# Altium schematic sheet 07_300WDC_POWER.SchDoc
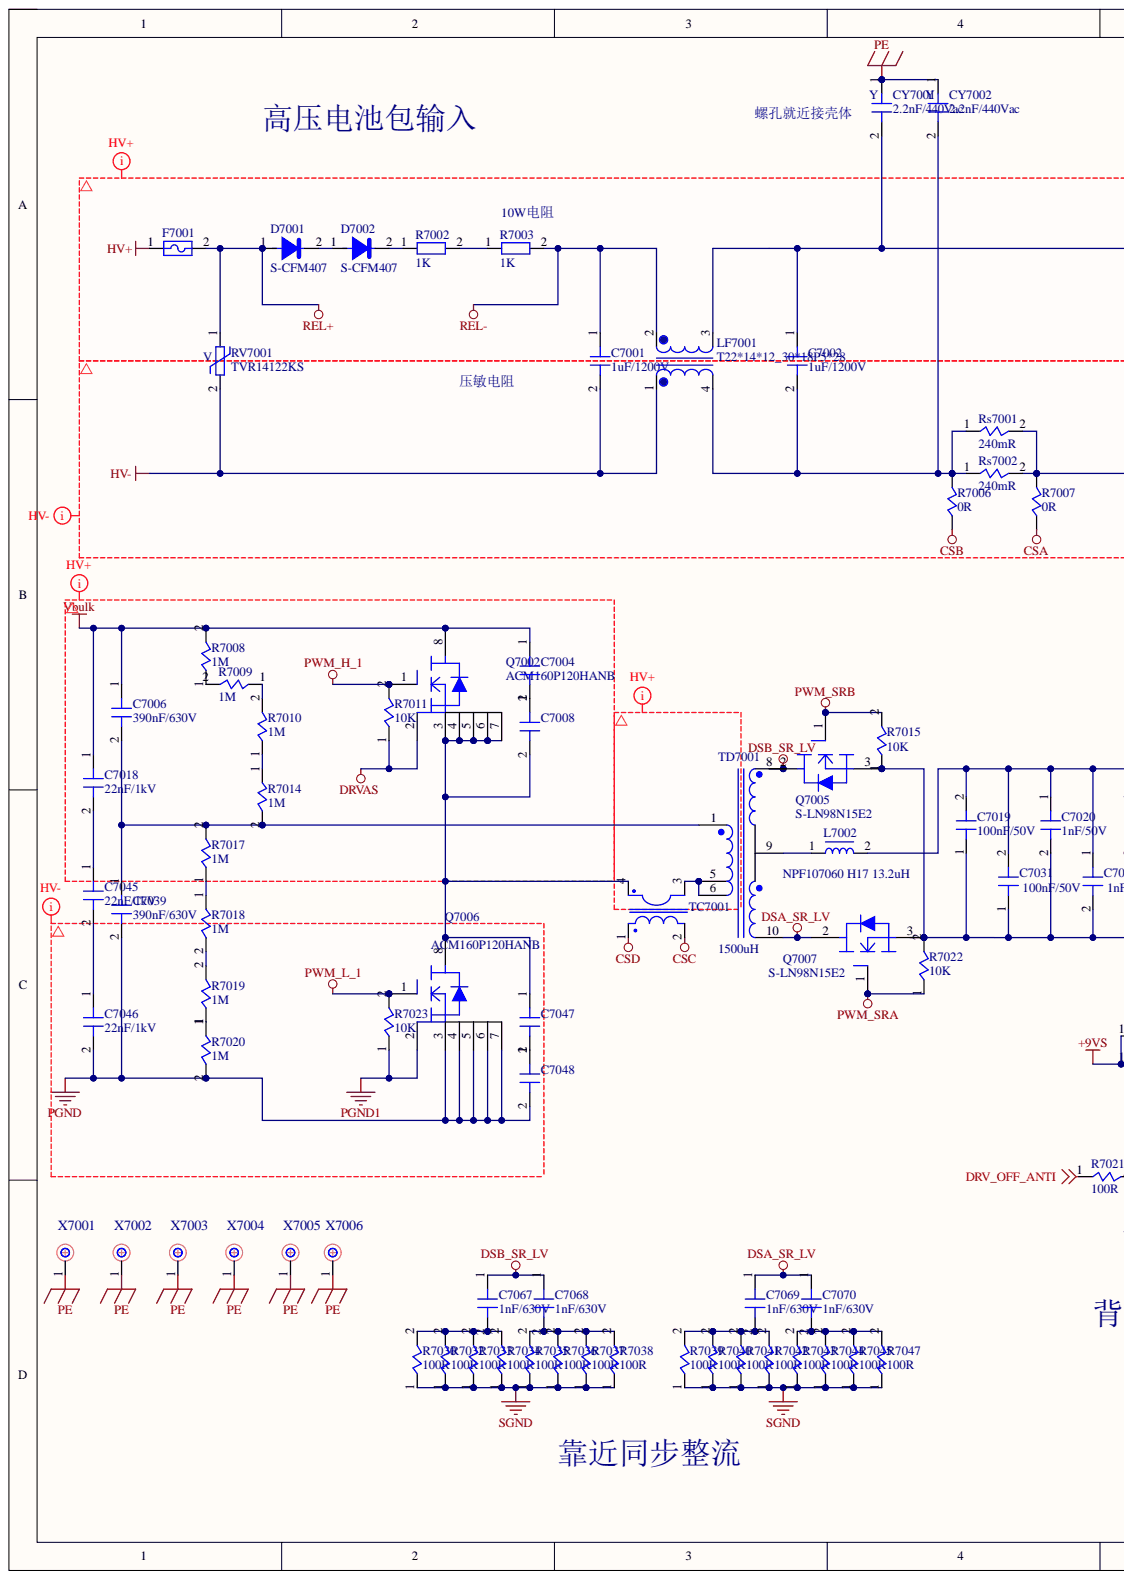
[diagram p0: 07_300WDC_POWER.SchDoc, left]
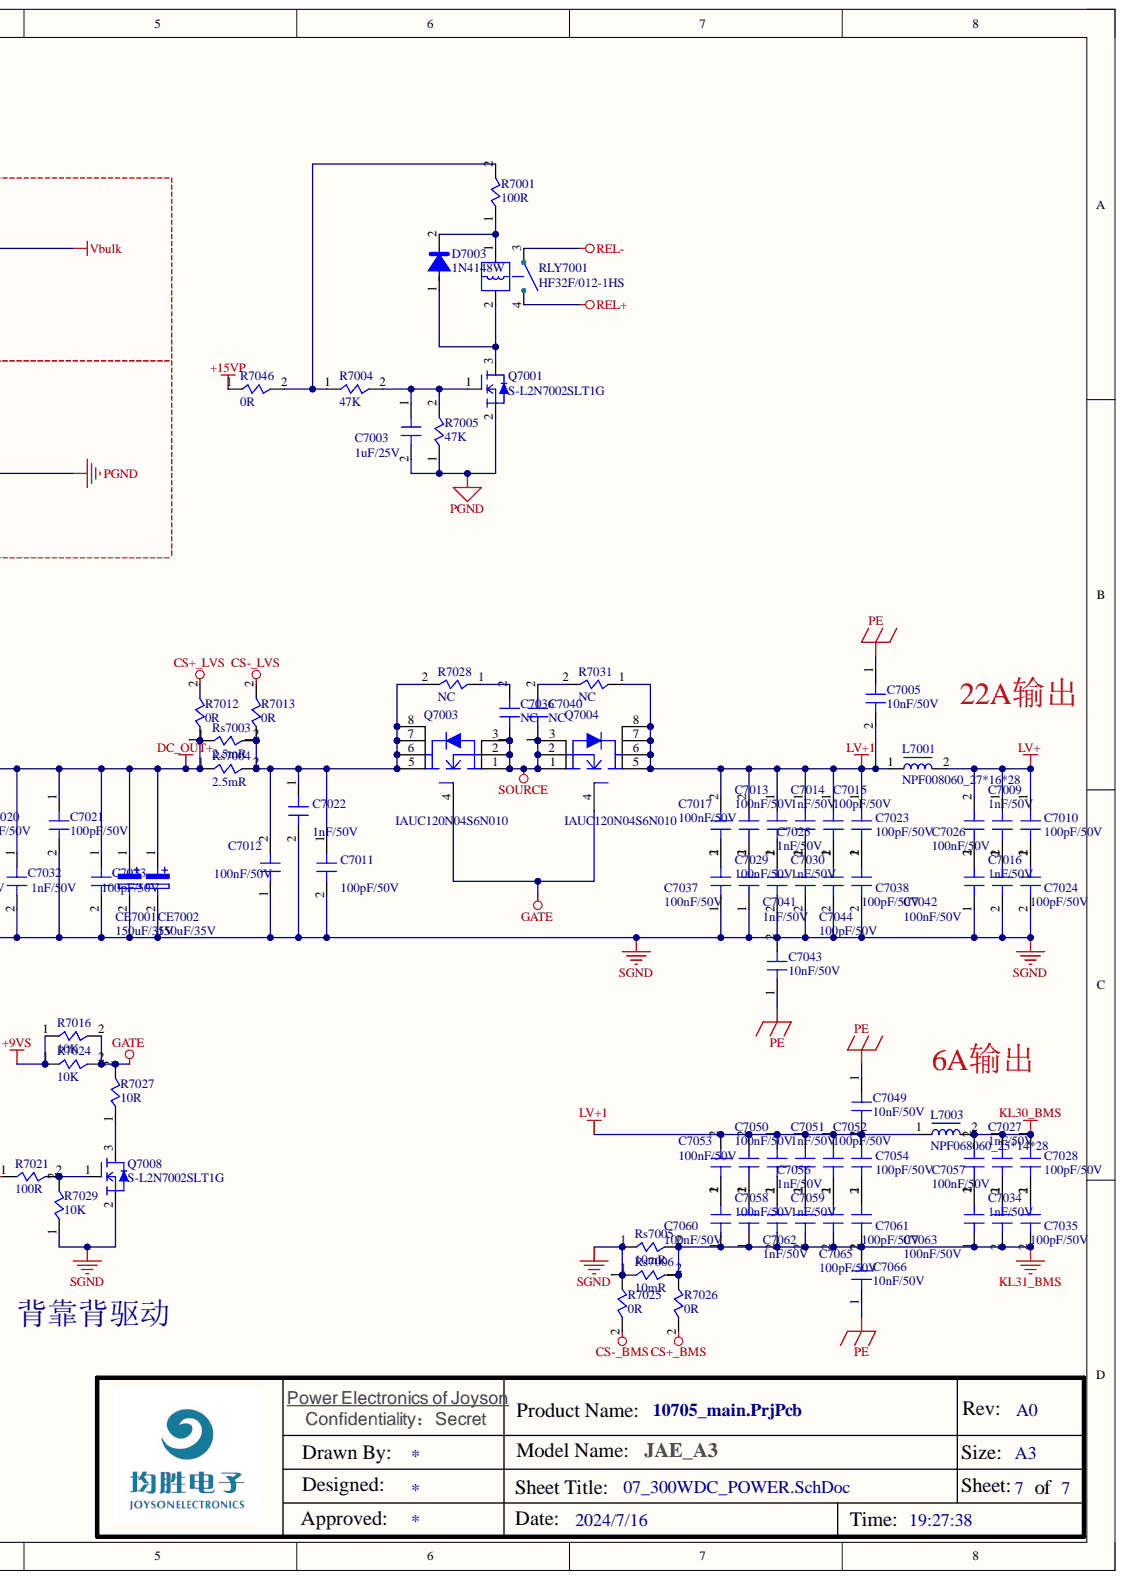
[diagram p1: 07_300WDC_POWER.SchDoc, right]

## Parts
C7001  1uF/1200V  [CF-32*11*20-P27.5]
C7002  1uF/1200V  [CF-32*11*20-P27.5]
C7003  1uF  value 1uF/25V  [C-0603]
C7004  1nF/1KV  [C-1206]  (value not drawn)  (no BOM)
C7005  10nF  value 10nF/50V  [C-0603]
C7006  390nF/630V  [CX-26*12*21.5-P22.5]
C7008  1nF/1KV  [C-1206]  (value not drawn)  (no BOM)
C7009  1nF  value 1nF/50V  [C-0805]
C7010  100pF  value 100pF/50V  [C-0805]
C7011  100pF  value 100pF/50V  [C-0805]
C7012  100nF  value 100nF/50V  [C-0805]
C7013  100nF  value 100nF/50V  [C-0805]
C7014  1nF  value 1nF/50V  [C-0805]
C7015  100pF  value 100pF/50V  [C-0805]
C7016  1nF  value 1nF/50V  [C-0805]
C7017  100nF  value 100nF/50V  [C-0805]
C7018  value 22nF/1kV  [C-1206]  (hidden comment: 33nF/630V)
C7019  100nF  value 100nF/50V  [C-0805]
C7020  1nF  value 1nF/50V  [C-0805]
C7021  100pF  value 100pF/50V  [C-0805]
C7022  1nF  value 1nF/50V  [C-0805]
C7023  100pF  value 100pF/50V  [C-0805]
C7024  100pF  value 100pF/50V  [C-0805]
C7025  1nF  value 1nF/50V  [C-0805]
C7026  100nF  value 100nF/50V  [C-0805]
C7027  1nF  value 1nF/50V  [C-0805]
C7028  100pF  value 100pF/50V  [C-0805]
C7029  100nF  value 100nF/50V  [C-0805]
C7030  1nF  value 1nF/50V  [C-0805]
C7031  100nF  value 100nF/50V  [C-0805]
C7032  1nF  value 1nF/50V  [C-0805]
C7033  100pF  value 100pF/50V  [C-0805]
C7034  1nF  value 1nF/50V  [C-0805]
C7035  100pF  value 100pF/50V  [C-0805]
C7036  NC  [C-1206]  (no BOM)
C7037  100nF  value 100nF/50V  [C-0805]
C7038  100pF  value 100pF/50V  [C-0805]
C7039  390nF/630V  [CX-26*12*21.5-P22.5]
C7040  NC  [C-1206]  (no BOM)
C7041  1nF  value 1nF/50V  [C-0805]
C7042  100nF  value 100nF/50V  [C-0805]
C7043  10nF  value 10nF/50V  [C-0603]
C7044  100pF  value 100pF/50V  [C-0805]
C7045  value 22nF/1kV  [C-1206]  (hidden comment: 33nF/630V)
C7046  value 22nF/1kV  [C-1206]  (hidden comment: 33nF/630V)
C7047  1nF/1KV  [C-1206]  (value not drawn)  (no BOM)
C7048  1nF/1KV  [C-1206]  (value not drawn)  (no BOM)
C7049  10nF  value 10nF/50V  [C-0603]
C7050  100nF  value 100nF/50V  [C-0805]
C7051  1nF  value 1nF/50V  [C-0805]
C7052  100pF  value 100pF/50V  [C-0805]
C7053  100nF  value 100nF/50V  [C-0805]
C7054  100pF  value 100pF/50V  [C-0805]
C7056  1nF  value 1nF/50V  [C-0805]
C7057  100nF  value 100nF/50V  [C-0805]
C7058  100nF  value 100nF/50V  [C-0805]
C7059  1nF  value 1nF/50V  [C-0805]
C7060  100nF  value 100nF/50V  [C-0805]
C7061  100pF  value 100pF/50V  [C-0805]
C7062  1nF  value 1nF/50V  [C-0805]
C7063  100nF  value 100nF/50V  [C-0805]
C7065  100pF  value 100pF/50V  [C-0805]
C7066  10nF  value 10nF/50V  [C-0603]
C7067  1nF/630V  [C-1206]
C7068  1nF/630V  [C-1206]
C7069  1nF/630V  [C-1206]
C7070  1nF/630V  [C-1206]
CE7001  150uF/35V  [CET-8*10.5]
CE7002  150uF/35V  [CET-8*10.5]
CY7001  2.2nF/440Vac  [CY-17.5*6*12-P15]
CY7002  2.2nF/440Vac  [CY-17.5*6*12-P15]
D7001  S-CFM407  [SMA]
D7002  S-CFM407  [SMA]
D7003  1N4148W  [SOD-123]
F7001  2.5A  [FUSE-32-5-5]  (value not drawn)
L7001  NPF008060_27*16*28  [NPF008060_27*16*28]
L7002  NPF107060 H17 13.2uH  [输出电感]
L7003  NPF068060_25*14*28  [NPF068060_25*14*28]
LF7001  T22*14*12_30*18P5*28  [T22*14*12_30*18P5*28]
Q7001  S-L2N7002SLT1G  [SOT-23]
Q7002  ACM160P120HANB  [TO-263-7]
Q7003  IAUC120N04S6N010  [TDSON-8]
Q7004  IAUC120N04S6N010  [TDSON-8]
Q7005  S-LN98N15E2  [TO-263]
Q7006  ACM160P120HANB  [TO-263-7]
Q7007  S-LN98N15E2  [TO-263]
Q7008  S-L2N7002SLT1G  [SOT-23]
R7001  100R  [R-1206]
R7002  1K  [RS-2W-V]
R7003  1K  [RS-2W-V]
R7004  47K  [R-0805]
R7005  47K  [R-0805]
R7006  0R  [R-0603]
R7007  0R  [R-0603]
R7008  1M  [R-1206]
R7009  1M  [R-1206]
R7010  1M  [R-1206]
R7011  10K  [R-0402]
R7012  0R  [R-0402]
R7013  0R  [R-0402]
R7014  1M  [R-1206]
R7015  10K  [R-0402]
R7016  10K  [R-1206]
R7017  1M  [R-1206]
R7018  1M  [R-1206]
R7019  1M  [R-1206]
R7020  1M  [R-1206]
R7021  100R  [R-0603]
R7022  10K  [R-0402]
R7023  10K  [R-0402]
R7024  10K  [R-1206]
R7025  0R  [R-0402]
R7026  0R  [R-0402]
R7027  10R  [R-1206]
R7028  NC  [R-1206]  (no BOM)
R7029  10K  [R-0603]
R7030  100R  [R-1206]
R7031  NC  [R-1206]  (no BOM)
R7032  100R  [R-1206]
R7033  100R  [R-1206]
R7034  100R  [R-1206]
R7035  100R  [R-1206]
R7036  100R  [R-1206]
R7037  100R  [R-1206]
R7038  100R  [R-1206]
R7039  100R  [R-1206]
R7040  100R  [R-1206]
R7041  100R  [R-1206]
R7042  100R  [R-1206]
R7043  100R  [R-1206]
R7044  100R  [R-1206]
R7045  100R  [R-1206]
R7046  0R  [R-0805]
R7047  100R  [R-1206]
RLY7001  HF32F/012-1HS  [HF32F/012-H]
Rs7001  240mR  [R-1206]
Rs7002  240mR  [R-1206]
Rs7003  2.5mR  [R-2512]
Rs7004  2.5mR  [R-2512]
Rs7005  10mR  [R-2512]
Rs7006  10mR  [R-2512]
RV7001  TVR14122KS  [VR-D14]
TC7001  TC100-EE10  [EE10-5X13X15-24]  (value not drawn)
TD7001  1500uH  [LLC-6X37P5X34-33]
X7001  M4  [M4*8]  (value not drawn)  (no BOM)
X7002  M4  [M4*8]  (value not drawn)  (no BOM)
X7003  M4  [M4*8]  (value not drawn)  (no BOM)
X7004  M4  [M4*8]  (value not drawn)  (no BOM)
X7005  M4  [M4*8]  (value not drawn)  (no BOM)
X7006  M4  [M4*8]  (value not drawn)  (no BOM)

## Nets
+9VS: R7016.1 R7024.1
+15VP: R7046.1
CS+_BMS: R7026.2
CS+_LVS: R7012.2
CS-_BMS: R7025.2
CS-_LVS: R7013.2
CSA: R7007.1
CSB: R7006.1
CSC: TC7001.2
CSD: TC7001.1
DC_OUT+: C7019.2 C7020.1 C7021.1 C7031.2 C7032.1 C7033.1 CE7001.1 CE7002.1 L7002.2 R7012.1 Rs7003.1 Rs7004.1
DRV_OFF_ANTI: R7021.1
DRVAS: Q7002.2 R7011.1
DSA_SR_LV: C7069.1 C7070.1 Q7007.2 TD7001.10
DSB_SR_LV: C7067.1 C7068.1 Q7005.2 TD7001.8
GATE: Q7003.4 Q7004.4 R7016.2 R7024.2 R7027.2
HV+: F7001.1
HV-: C7001.2 LF7001.1 RV7001.2
KL30_BMS: C7027.1 C7028.1 C7057.2 L7003.2
KL31_BMS: C7034.2 C7035.2 C7058.1 C7059.2 C7060.1 C7061.2 C7062.2 C7063.1 C7065.2 C7066.2 R7026.1 Rs7005.2 Rs7006.2
LV+: C7009.1 C7010.1 C7026.2 L7001.2
LV+1: C7005.2 C7013.2 C7014.1 C7015.1 C7017.2 C7023.1 C7025.1 C7049.2 C7050.2 C7051.1 C7052.1 C7053.2 C7054.1 C7056.1 L7001.1 L7003.1 Q7004.5 Q7004.6 Q7004.7 Q7004.8 R7031.1
PE: C7005.1 C7043.1 C7049.1 C7066.1 CY7001.1 CY7002.1 X7001.1 X7002.1 X7003.1 X7004.1 X7005.1 X7006.1
PGND: C7003.2 C7039.2 C7046.2 C7048.2 Q7001.2 Q7006.3 Q7006.4 Q7006.5 Q7006.6 Q7006.7 R7005.1 R7007.2 R7020.2 Rs7001.2 Rs7002.2
PGND1: Q7006.2 R7023.1
PWM_H_1: Q7002.1 R7011.2
PWM_L_1: Q7006.1 R7023.2
PWM_SRA: Q7007.1 R7022.1
PWM_SRB: Q7005.1 R7015.2
REL+: D7001.1 F7001.2 RLY7001.4 RV7001.1
REL-: C7001.1 LF7001.2 R7003.2 RLY7001.3
SGND: C7011.2 C7012.1 C7016.2 C7019.1 C7020.2 C7021.2 C7022.2 C7024.2 C7029.1 C7030.2 C7031.1 C7032.2 C7033.2 C7037.1 C7038.2 C7041.2 C7042.1 C7043.2 C7044.2 CE7001.2 CE7002.2 Q7005.3 Q7007.3 Q7008.2 R7015.1 R7022.2 R7025.1 R7029.1 R7030.1 R7032.1 R7033.1 R7034.1 R7035.1 R7036.1 R7037.1 R7038.1 R7039.1 R7040.1 R7041.1 R7042.1 R7043.1 R7044.1 R7045.1 R7047.1 Rs7005.1 Rs7006.1
SOURCE: C7036.1 C7040.1 Q7003.1 Q7003.2 Q7003.3 Q7004.1 Q7004.2 Q7004.3
Vbulk: C7002.1 C7004.1 C7006.1 C7018.1 CY7001.2 LF7001.3 Q7002.8 R7008.2
NetC7002_2: C7002.2 CY7002.2 LF7001.4 R7006.2 Rs7001.1 Rs7002.1
NetC7003_1: C7003.1 Q7001.1 R7004.2 R7005.2
NetC7004_2: C7004.2 C7008.1
NetC7006_2: C7006.2 C7039.1 R7014.2 R7017.2 TD7001.1
NetC7008_2: C7008.2 C7047.1 Q7002.3 Q7002.4 Q7002.5 Q7002.6 Q7002.7 Q7006.8 TC7001.4
NetC7009_2: C7009.2 C7016.1
NetC7010_2: C7010.2 C7024.1
NetC7011_1: C7011.1 C7012.2 C7022.1 Q7003.5 Q7003.6 Q7003.7 Q7003.8 R7013.1 R7028.2 Rs7003.2 Rs7004.2
NetC7013_1: C7013.1 C7029.2
NetC7014_2: C7014.2 C7030.1
NetC7015_2: C7015.2 C7044.1
NetC7017_1: C7017.1 C7037.2
NetC7018_2: C7018.2 C7045.1
NetC7023_2: C7023.2 C7038.1
NetC7025_2: C7025.2 C7041.1
NetC7026_1: C7026.1 C7042.2
NetC7027_2: C7027.2 C7034.1
NetC7028_2: C7028.2 C7035.1
NetC7036_2: C7036.2 R7028.1
NetC7040_2: C7040.2 R7031.2
NetC7045_2: C7045.2 C7046.1
NetC7047_2: C7047.2 C7048.1
NetC7050_1: C7050.1 C7058.2
NetC7051_2: C7051.2 C7059.1
NetC7052_2: C7052.2 C7065.1
NetC7053_1: C7053.1 C7060.2
NetC7054_2: C7054.2 C7061.1
NetC7056_2: C7056.2 C7062.1
NetC7057_1: C7057.1 C7063.2
NetC7067_2: C7067.2 R7030.2 R7032.2 R7033.2 R7034.2
NetC7068_2: C7068.2 R7035.2 R7036.2 R7037.2 R7038.2
NetC7069_2: C7069.2 R7039.2 R7040.2 R7041.2 R7042.2
NetC7070_2: C7070.2 R7043.2 R7044.2 R7045.2 R7047.2
NetD7001_2: D7001.2 D7002.1
NetD7002_2: D7002.2 R7002.1
NetD7003_1: D7003.1 Q7001.3 RLY7001.2
NetD7003_2: D7003.2 R7001.1 RLY7001.1
NetL7002_1: L7002.1 TD7001.9
NetQ7008_1: Q7008.1 R7021.2 R7029.2
NetQ7008_3: Q7008.3 R7027.1
NetR7001_2: R7001.2 R7004.1 R7046.2
NetR7002_2: R7002.2 R7003.1
NetR7008_1: R7008.1 R7009.2
NetR7009_1: R7009.1 R7010.2
NetR7010_1: R7010.1 R7014.1
NetR7017_1: R7017.1 R7018.1
NetR7018_2: R7018.2 R7019.2
NetR7019_1: R7019.1 R7020.1
NetTC7001_3: TC7001.3 TD7001.5 TD7001.6

## Library data of the parts
C7001 C7002 = 1104030003-00: 无极性电容封装; Brand: 法拉; Database Table Name: Capacitor-RAD; Grade: 工业级; Material Name: Capacitor; Spec/Modle: Capacitor-film-C3D-1200V-1uF-±10%-W*T*H=32*22*37mm-P27.5-L5; Temprature: -40℃ to +105℃; Type: 插件; Vendor No: C3D3L105KB00C00; Component Kind: Standard; Library Name: Database_Libs1.DbLib; Material No: 1104030003-00; Value: 1uF/1200V
C7003 = 1101022035-00: 贴片电容封装; Brand: YAGEO; Database Table Name: Capacitor-SMD; Grade: 汽车级; Material Name: Capacitor; Spec/Modle: Capacitor-MLCC-0603-X7R-±10%-25V-1uF; Temprature: -55℃ to +125℃; Type: 贴片; Vendor No: AC0603KPX7R8BB105; Component Kind: Standard; Library Name: Database_Libs1.DbLib; Material No: 1101022035-00; Value2: 1uF
C7004 C7008 C7036 C7040 C7047 C7048 = 1101042007-00: 贴片电容封装; Brand: YAGEO; Database Table Name: Capacitor-SMD; Grade: 汽车级; Material Name: Capacitor; Spec/Modle: Capacitor-MLCC-1206-X7R-±10%-1000V-1nF; Temprature: -55℃ to +125℃; Type: 贴片; Vendor No: AC1206KKX7RCBB102; Library Name: Database_Libs1.DbLib; Material No: 1101042007-00
  C7004 C7008 C7047 C7048: Value: 1nF/1kV; Value2: 1nF/1KV
  C7036 C7040: Value: NC; Value2: NC
C7005 C7043 C7049 C7066 = 1101022019-00: 贴片电容封装; Brand: YAGEO; Database Table Name: Capacitor-SMD; Grade: 汽车级; Material Name: Capacitor; Spec/Modle: Capacitor-MLCC-0603-X7R-±10%-50V-10nF; Temprature: -55℃ to +125℃; Type: 贴片; Vendor No: AC0603KRX7R9BB103; Component Kind: Standard; Library Name: Database_Libs1.DbLib; Material No: 1101022019-00; Value2: 10nF
C7006 C7039 = 1104030004-00: 无极性电容封装; Brand: 法拉; Database Table Name: Capacitor-RAD; Grade: 汽车级; Material Name: Capacitor; Spec/Modle: Capacitor-film-C3D-1200V-1uF-±10%-W*T*H=32*22*37mm-P27.5-L5; Temprature: -40℃ to +105℃; Type: 插件; Vendor No: D822J394-90; Component Kind: Standard; Library Name: Database_Libs1.DbLib; Material No: 1104030004-00; Value: 390nF/630V
C7009 C7014 C7016 C7020 C7022 C7025 C7027 C7030 C7032 C7034 C7041 C7051 C7056 C7059 C7062 = 1101032010-00: 贴片电容封装; Brand: YAGEO; Database Table Name: Capacitor-SMD; Grade: 汽车级; Material Name: Capacitor; Spec/Modle: Capacitor-MLCC-0805-X7R-±10%-50V-1nF; Temprature: -55℃ to +125℃; Type: 贴片; Vendor No: AC0805KRX7R9BB102; Component Kind: Standard; Library Name: Database_Libs1.DbLib; Material No: 1101032010-00; Value2: 1nF
C7010 C7011 C7015 C7021 C7023 C7024 C7028 C7033 C7035 C7038 C7044 C7052 C7054 C7061 C7065 = 1101032001-00: 贴片电容封装; Brand: YAGEO; Database Table Name: Capacitor-SMD; Grade: 汽车级; Material Name: Capacitor; Spec/Modle: Capacitor-MLCC-0805-X7R-±10%-50V-100pF; Temprature: -55℃ to +125℃; Type: 贴片; Vendor No: AC0805KRX7R9BB101; Component Kind: Standard; Library Name: Database_Libs1.DbLib; Material No: 1101032001-00; Value2: 100pF
C7012 C7013 C7017 C7019 C7026 C7029 C7031 C7037 C7042 C7050 C7053 C7057 C7058 C7060 C7063 = 1101032028-00: 贴片电容封装; Brand: YAGEO; Database Table Name: Capacitor-SMD; Grade: 汽车级; Material Name: Capacitor; Spec/Modle: Capacitor-MLCC-0805-X7R-±10%-50V-100nF; Temprature: -55℃ to +125℃; Type: 贴片; Vendor No: AC0805KRX7R9BB104; Component Kind: Standard; Library Name: Database_Libs1.DbLib; Material No: 1101032028-00; Value2: 100nF
C7018 C7045 C7046 = 1101042012-00: 贴片电容封装; Brand: Walsin; Database Table Name: Capacitor-SMD; Grade: 工业级; Material Name: Capacitor; Spec/Modle: Capacitor-MLCC-1206-X7R-±10%-1kV-22nF; Temprature: -55℃ to +125℃; Type: 贴片; Vendor No: 1206B333K631CT; Component Kind: Standard; Library Name: Database_Libs1.DbLib; Material No: 1101042012-00; Value2: 33nF/630V
C7067-C7070 = 1101040025-00: 贴片电容封装; Brand: YAGEO; Database Table Name: Capacitor-SMD; Grade: 汽车级; Material Name: Capacitor; Spec/Modle: Capacitor-MLCC-1206-NPO-±5%-630V-1nF; Temprature: -55℃ to +125℃; Type: 贴片; Vendor No: AC1206JKNPOZBN102; Component Kind: Standard; Library Name: Database_Libs1.DbLib; Material No: 1101040025-00; Value: 1nF/630V; Value2: 1nF/630V
CE7001 CE7002 = 1102051001-00: 铝电解电容封装; Brand: LELON; Database Table Name: Capacitor-ELE; ESR: 27mΩ; Grade: 工业级; Material Name: Capacitor; Ripple Current: 2300mA; SAP Number: 06005-488/0000; Spec/Modle: Capacitor-EC-HBV-35V-150uF-±20%-10000h-φ8*10-P3.1; Temprature: -55℃ to +105℃; Vendor No: HBV151M1VTR-0810KEGT; Component Kind: Standard; Library Name: Database_Libs1.DbLib; Material No: 1102051001-00; Type: 贴片; Value: 150uF/35V
CY7001 CY7002 = 1105030001-00: Y电容封装; Brand: 法拉; Database Table Name: Capacitor-RAD; Grade: 汽车级; Material Name: Capacitor; Spec/Modle: Capacitor-Y1-MKP-440Vac-2.2nF-±10%-W*T*H=17.5*6*12-P15-L5; Temprature: -40℃ to +110℃; Vendor No: D47S1222K; Component Kind: Standard; Library Name: Database_Libs1.DbLib; Material No: 1105030001-00; Type: 插件; Value: 2.2nF/440Vac
D7001 D7002 = 1201090001-00: 二极管SMD-2P封装; Brand: LRC; Database Table Name: Diode; Grade: 工业级; Spec/Modle: Diode-SMD-SMA-1000V-1A; Temprature: -50℃ to +150℃; Type: 贴片; Component Kind: Standard; Library Name: Database_Libs1.DbLib; Material Name: Diode; Material No: 1201090001-00; Value: S-CFM407; Vendor No: S-CFM407
D7003 = 1205030001-00: 二极管SMD-2P封装; Brand: 宏迦橙; Database Table Name: Diode; Grade: 工业级; Spec/Modle: Diode-SFR-SOD123-100V-150mA; Temprature: -55℃ to +150℃; Type: 贴片; Component Kind: Standard; Library Name: Database_Libs1.DbLib; Material Name: Diode; Material No: 1205030001-00; Value: 1N4148W; Vendor No: 1N4148W
F7001 = 1080204003: 保险丝通用封装; Brand: BELFuse; Database Table Name: Other; Grade: 汽车级; Material Name: 保险丝; Spec/Modle: FUSE-SMD-0ACF-1000V-2.5A; Temprature: -40℃ to +105℃; Type: 贴片; Vendor No: 0ACF-2500-2.5; Component Kind: Standard; Library Name: Database_Libs1.DbLib; Value: 2.5A
L7001 = 1502050003-00: 电感DIP封装; Brand: 南京中策; Database Table Name: Magnetic; Grade: 工业级; Material Name: Inductor; Spec/Modle: Inductor-NPF008060-1uH-±5%-φ1.6*2P-6T; Temprature: -40℃ to +125℃; Type: 插件; Component Kind: Standard; Library Name: Database_Libs1.DbLib; Material No: 1502050003-00; Value: NPF008060_27*16*28; Vendor No: NPF008060_27*16*28
L7002 = 1502050005-00: 电感DIP封装; Brand: 南京中策; Database Table Name: Magnetic; Grade: 工业级; Material Name: Inductor; Spec/Modle: Inductor-NPF107060-13.2uH-±5%-φ1.6*2P-10T; Temprature: -40℃ to +125℃; Type: 插件; Component Kind: Standard; Library Name: Database_Libs1.DbLib; Material No: 1502050005-00; Value: NPF107060 H17 13.2uH; Vendor No: NPF107060 H17
L7003 = 1502050004-00: 电感DIP封装; Brand: 南京中策; Database Table Name: Magnetic; Grade: 工业级; Material Name: Inductor; Spec/Modle: Inductor-NPF068060-1.5uH-±5%-φ1.2*1P-6T; Temprature: -40℃ to +125℃; Type: 插件; Component Kind: Standard; Library Name: Database_Libs1.DbLib; Material No: 1502050004-00; Value: NPF068060_25*14*28; Vendor No: NPF068060_25*14*28
LF7001 = 1504020005-00: 共模电感DIP封装; Brand: 南京中策; Database Table Name: Magnetic; Grade: 工业级; Material Name: Inductor; Spec/Modle: Inductor-CMC-30*18P5*28-3mH; Temprature: -40℃ to +125℃; Component Kind: Standard; Library Name: Database_Libs1.DbLib; Material No: 1504020005-00; Type: 插件; Value: T22*14*12_30*18P5*28; Vendor No: T22*14*12_30*18P5*28
Q7001 Q7008 = 1304030001-00: NMOS管SOT23封装; Brand: LRC; Database Table Name: Transistor; Grade: 汽车级; Material Name: Mosfet; SAP Number: 06005-431/0000; Spec/Modle: Mosfet-NMOS-SOT23-60V-380mA-3.2Ω-mark(701); Temprature: -55℃ to +150℃; Type: 贴片; Component Kind: Standard; Library Name: Database_Libs1.DbLib; Material No: 1304030001-00; Value: S-L2N7002SLT1G; Vendor No: S-L2N7002SLT1G
Q7002 Q7006 = 1304130002-00: NMOS管TO-263-7封装; Brand: Alpha; Database Table Name: Transistor; Grade: 汽车级; Material Name: Mosfet; Spec/Modle: Mosfet-NMOS-TO263-7-1200V-18A-160mΩ-; Temprature: -55℃ to +175℃; Type: 贴片; Component Kind: Standard; Library Name: Database_Libs1.DbLib; Material No: 1304130002-00; Value: ACM160P120HANB; Vendor No: ACM160P120HANB
Q7003 Q7004 = 1304160001-00: NMOS管PG-TDSON-8; Brand: infineon; Database Table Name: Transistor; Grade: 汽车级; Material Name: Mosfet; Spec/Modle: Mosfet-NMOS-TDSON-40V-120A-1mΩ-mark(6N04N010); Temprature: -55℃ to +175℃; Type: 贴片; Component Kind: Standard; Library Name: Database_Libs1.DbLib; Material No: 1304160001-00; Value: IAUC120N04S6N010; Vendor No: IAUC120N04S6N010
Q7005 Q7007 = 1304130003-00: NMOS管TO3P封装; Brand: LRC; Database Table Name: Transistor; Grade: 汽车级; Material Name: Mosfet; Spec/Modle: Mosfet-NMOS-TO263-150V-1155A-6.2mΩ-mark(98N15E2); Temprature: -55℃ to +175℃; Type: 贴片; Component Kind: Standard; Library Name: Database_Libs1.DbLib; Material No: 1304130003-00; Value: S-LN98N15E2; Vendor No: S-LN98N15E2
R7001 R7030 R7032-R7045 R7047 = 1001040229-00: 贴片电阻封装; Brand: YAGEO; Database Table Name: Resistor-SMD; Grade: 汽车级; Material Name: Resistor; SAP Number: 05541-249/0000; Spec/Modle: Resistor-SMD-1206-1/4W-±1%-±100ppm/℃-100Ω; Temprature: -55℃ to +155℃; Vendor No: AC1206FR-07100RL; Component Kind: Standard; Library Name: Database_Libs1.DbLib; Material No: 1001040229-00; Type: 贴片; Value: 100R
R7002 R7003 = 1004100073-00: 插件电阻封装; Brand: YAGEO; Database Table Name: Resistor-other; Grade: 工业级; Material Name: Resistor; Spec/Modle: Resistor-MO-3WS-±5%-±300ppm/℃-1KΩ; Temprature: -55℃ to +155℃; Vendor No: RSF3WSJT-73-1K; Component Kind: Standard; Library Name: Database_Libs1.DbLib; Material No: 1004100073-00; Type: 插件; Value: 1K
R7004 R7005 = 1001030533-00: 贴片电阻封装; Brand: YAGEO; Database Table Name: Resistor-SMD; Grade: 汽车级; Material Name: Resistor; SAP Number: 05542-553/0000; Spec/Modle: Resistor-SMD-0805-1/8W-±1%-±100ppm/℃-47KΩ; Temprature: -55℃ to +155℃; Vendor No: AC0805FR-0747KL; Component Kind: Standard; Library Name: Database_Libs1.DbLib; Material No: 1001030533-00; Type: 贴片; Value: 47K
R7006 R7007 = RES-0603: Brand: YAGEO; Material Name: Resistor; SAP Number: 06005-226/0000; Spec/Modle: SMD,RES,0603,0R,J,1/10W; Vendor No: AC0603JR-070RL; Component Kind: Standard; Library Name: my lib.schlib
R7008-R7010 R7014 R7017-R7020 = 1001040685-00: 贴片电阻封装; Brand: YAGEO; Database Table Name: Resistor-SMD; Grade: 汽车级; Material Name: Resistor; SAP Number: 05541-705/0000; Spec/Modle: Resistor-SMD-1206-1/4W-±1%-±100ppm/℃-1MΩ; Temprature: -55℃ to +155℃; Vendor No: AC1206FR-071ML; Component Kind: Standard; Library Name: Database_Libs1.DbLib; Material No: 1001040685-00; Type: 贴片; Value: 1M
R7011 R7015 R7022 R7023 = 1001010457-00: 贴片电阻封装; Brand: YAGEO; Database Table Name: Resistor-SMD; Grade: 汽车级; Material Name: Resistor; SAP Number: 05544-477/0000; Spec/Modle: Resistor-SMD-0402-1/16W-±1%-±100ppm/℃-10KΩ; Temprature: -55℃ to +155℃; Vendor No: AC0402FR-0710KL; Component Kind: Standard; Library Name: Database_Libs1.DbLib; Material No: 1001010457-00; Type: 贴片; Value: 10K
R7012 R7013 R7025 R7026 = 1001010000-00: 贴片电阻封装; Brand: YAGEO; Database Table Name: Resistor-SMD; Grade: 汽车级; Material Name: Resistor; SAP Number: 05544-820/0000; Spec/Modle: Resistor-SMD-0402-1/16W-±5%-0Ω; Temprature: -55℃ to +155℃; Vendor No: AC0402JR-070RL; Component Kind: Standard; Library Name: Database_Libs1.DbLib; Material No: 1001010000-00; Type: 贴片; Value: 0R
R7016 R7024 = 1001040457-00: 贴片电阻封装; Brand: YAGEO; Database Table Name: Resistor-SMD; Grade: 汽车级; Material Name: Resistor; SAP Number: 05541-477/0000; Spec/Modle: Resistor-SMD-1206-1/4W-±1%-±100ppm/℃-10KΩ; Temprature: -55℃ to +155℃; Vendor No: AC1206FR-0710KL; Component Kind: Standard; Library Name: Database_Libs1.DbLib; Material No: 1001040457-00; Type: 贴片; Value: 10K
R7021 = 1001020229-00: 贴片电阻封装; Brand: YAGEO; Database Table Name: Resistor-SMD; Grade: 汽车级; Material Name: Resistor; SAP Number: 05543-249/0000; Spec/Modle: Resistor-SMD-0603-1/10W-±1%-±100ppm/℃-100Ω; Temprature: -55℃ to +155℃; Vendor No: AC0603FR-07100RL; Component Kind: Standard; Library Name: Database_Libs1.DbLib; Material No: 1001020229-00; Type: 贴片; Value: 100R
R7027 = 1001040115-00: 贴片电阻封装; Brand: YAGEO; Database Table Name: Resistor-SMD; Grade: 汽车级; Material Name: Resistor; SAP Number: 05541-135/0000; Spec/Modle: Resistor-SMD-1206-1/4W-±1%-±200ppm/℃-10Ω; Temprature: -55℃ to +155℃; Vendor No: AC1206FR-0710RL; Component Kind: Standard; Library Name: Database_Libs1.DbLib; Material No: 1001040115-00; Type: 贴片; Value: 10R
R7028 R7031 = 1001040552-00: 贴片电阻封装; Brand: YAGEO; Database Table Name: Resistor-SMD; Grade: 汽车级; Material Name: Resistor; SAP Number: 05541-572/0000; Spec/Modle: Resistor-SMD-1206-1/4W-±1%-±100ppm/℃-68KΩ; Temprature: -55℃ to +155℃; Vendor No: AC1206FR-0768KL; Library Name: Database_Libs1.DbLib; Material No: 1001040552-00; Type: 贴片; Value: NC
R7029 = 1001020457-00: 贴片电阻封装; Brand: YAGEO; Database Table Name: Resistor-SMD; Grade: 汽车级; Material Name: Resistor; SAP Number: 05543-477/0000; Spec/Modle: Resistor-SMD-0603-1/10W-±1%-±100ppm/℃-10KΩ; Temprature: -55℃ to +155℃; Vendor No: AC0603FR-0710KL; Component Kind: Standard; Library Name: Database_Libs1.DbLib; Material No: 1001020457-00; Type: 贴片; Value: 10K
R7046 = 1001030000-00: 贴片电阻封装; Brand: YAGEO; Database Table Name: Resistor-SMD; Grade: 汽车级; Material Name: Resistor; SAP Number: 05542-820/0000; Spec/Modle: Resistor-SMD-0805-1/8W-±5%-0Ω; Temprature: -55℃ to +155℃; Vendor No: AC0805JR-070RL; Component Kind: Standard; Library Name: Database_Libs1.DbLib; Material No: 1001030000-00; Type: 贴片; Value: 0R
RLY7001 = 1906040001-00: 继电器1组常开封装; Brand: 宏发; Database Table Name: Other; Grade: 工业级; Material name: Relay; Specification: Relay-250VAC-5A-12V-320Ω; Temprature: -40℃ to +70℃; Component Kind: Standard; Library Name: Database_Libs1.DbLib; Material No: 1906040001-00; Order code: HF32F/012-1HS; Type: 插件; Value: HF32F/012-1HS
Rs7001 Rs7002 = 1002040015-00: 电流采样电阻封装; Brand: YAGEO; Database Table Name: Resistor-SMD; Grade: 汽车级; Material Name: Resistor; Spec/Modle: Resistor-CS-1206-1/4W-±1%-±75ppm/℃-240mΩ; Temprature: -55℃ to +155℃; Vendor No: PT1206FR-070R24L; Component Kind: Standard; Library Name: Database_Libs1.DbLib; Material No: 1002040015-00; Type: 贴片; Value: 240mR
Rs7003 Rs7004 = 1002060008-01: 电流采样电阻封装; Brand: YAGEO; Database Table Name: Resistor-SMD; Grade: 汽车级; Material Name: Resistor; Material No: 1002060007-01; Spec/Modle: Resistor-CS-2512-3W-±1%-±75ppm/℃-2.5mΩ; Temprature: -55℃ to +170℃; Vendor No: PA2512FKM7T0R0025E; Component Kind: Standard; Library Name: Database_Libs1.DbLib; Type: 贴片; Value: 2.5mR
Rs7005 Rs7006 = 1002060017-00: 电流采样电阻封装; Brand: 毫欧; Database Table Name: Resistor-SMD; Grade: 工业级; Material Name: Resistor; Spec/Modle: Resistor-CS-2512-3W-±1%-±50ppm/℃-10mΩ; Temprature: -55℃ to +170℃; Vendor No: HoLLR2512-3W-10mR-1%; Component Kind: Standard; Library Name: Database_Libs1.DbLib; Material No: 1002060017-00; Type: 贴片; Value: 10mR
RV7001 = 1009040005-00: 压敏电阻封装; Brand: 兴勤; Database Table Name: Resistor-other; Grade: 工业级; Material Name: Varistor; Spec/Modle: Resistor-varistor-TVR-φ14mm-1200Vdc; Temprature: -40℃ to +105℃; Component Kind: Standard; Library Name: Database_Libs1.DbLib; Material No: 1009040005-00; Type: 插件; Value: TVR14122KS; Vendor No: TVR14122KS
TC7001 = 1060602001: 电流互感器; Brand: 南京中策; Grade: 工业级; Spec/Modle: Transformer-Drive-EE10H(2+2)-150T; Temprature: -40℃ to +125℃; Component Kind: Standard; Library Name: CT-100-1.SCHLIB; Material Name: 电流互感器; Type: 插件; Value: TC100-EE10; Vendor No: TC100-EE10
TD7001 = 1060502002: PQ3517; Grade: 工业级; Material name: Transformer; Specification: Transformer-Drive-EP13H(5+5)-20:20:20; Temprature: -40℃ to +125℃; Component Kind: Standard; Library Name: LLC_L.SCHLIB; Type: 插件
X7001-X7006 = M3: Library Name: ME.IntLib
